# Altium schematic sheet CDU_Supply.SchDoc(CDU_Supply)
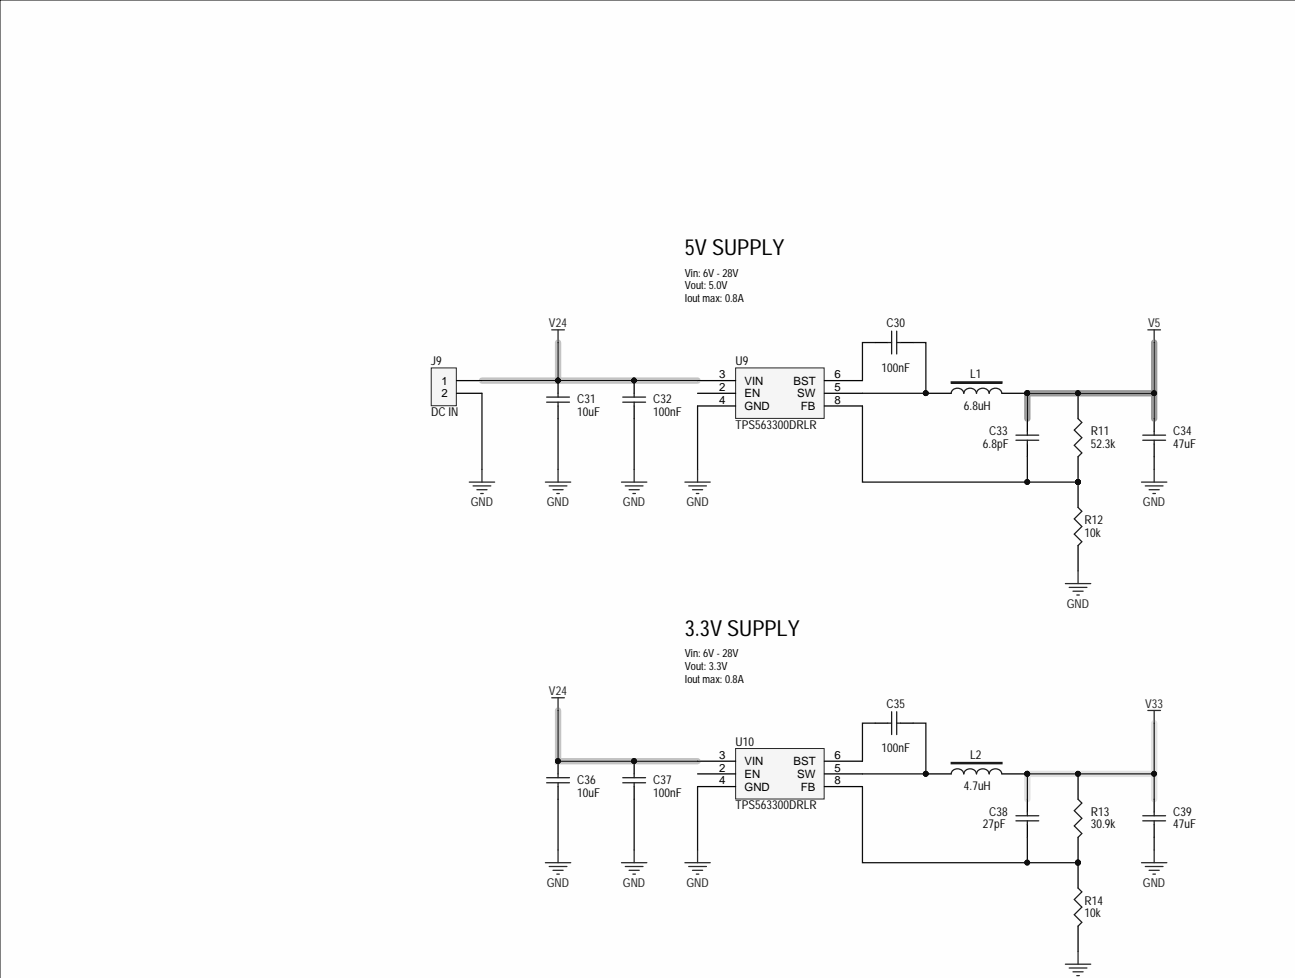
[diagram p0: CDU_Supply.SchDoc, top left]
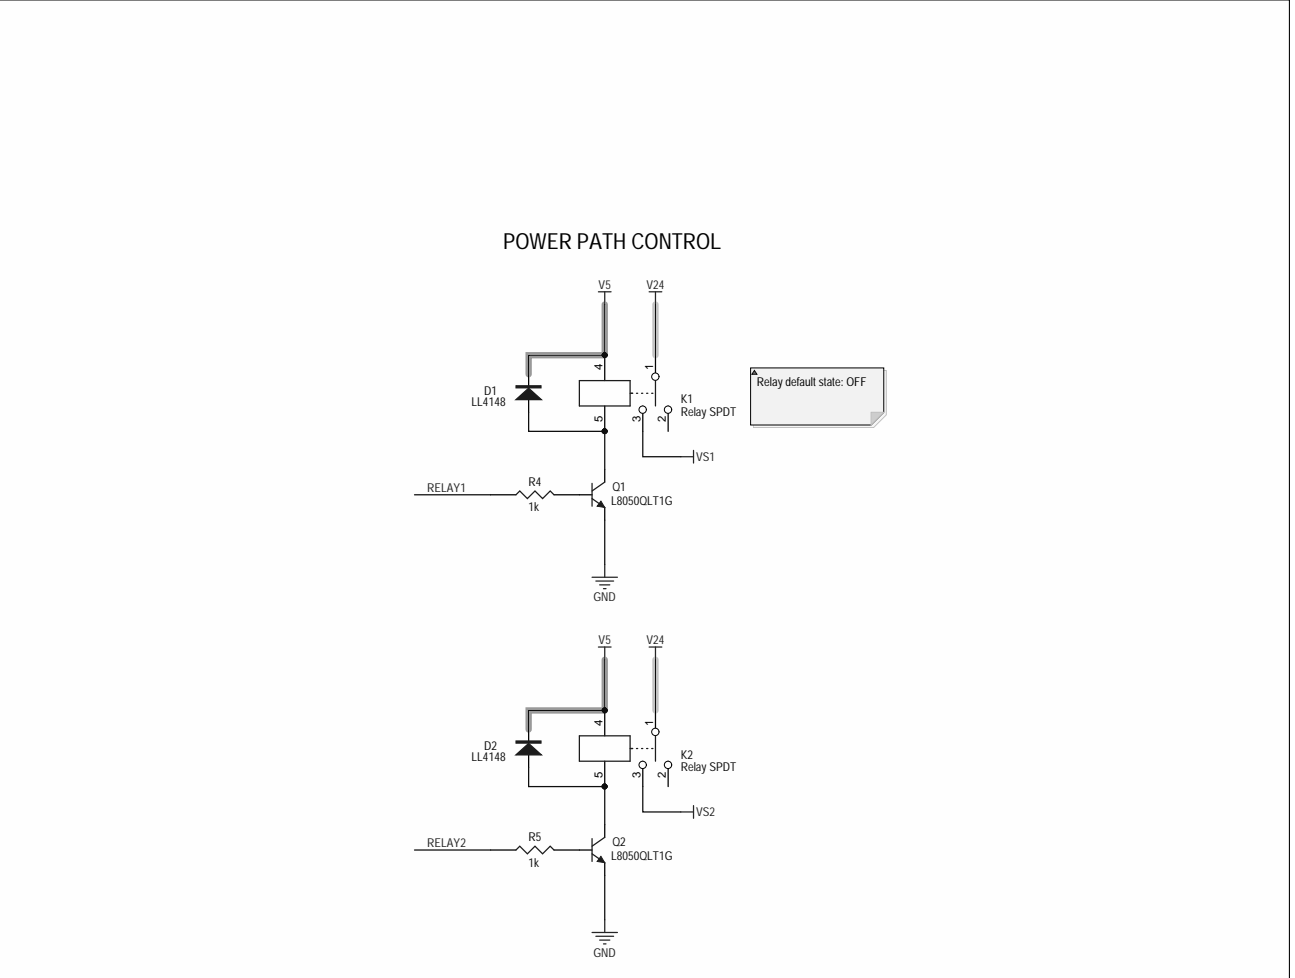
[diagram p1: CDU_Supply.SchDoc, top right]
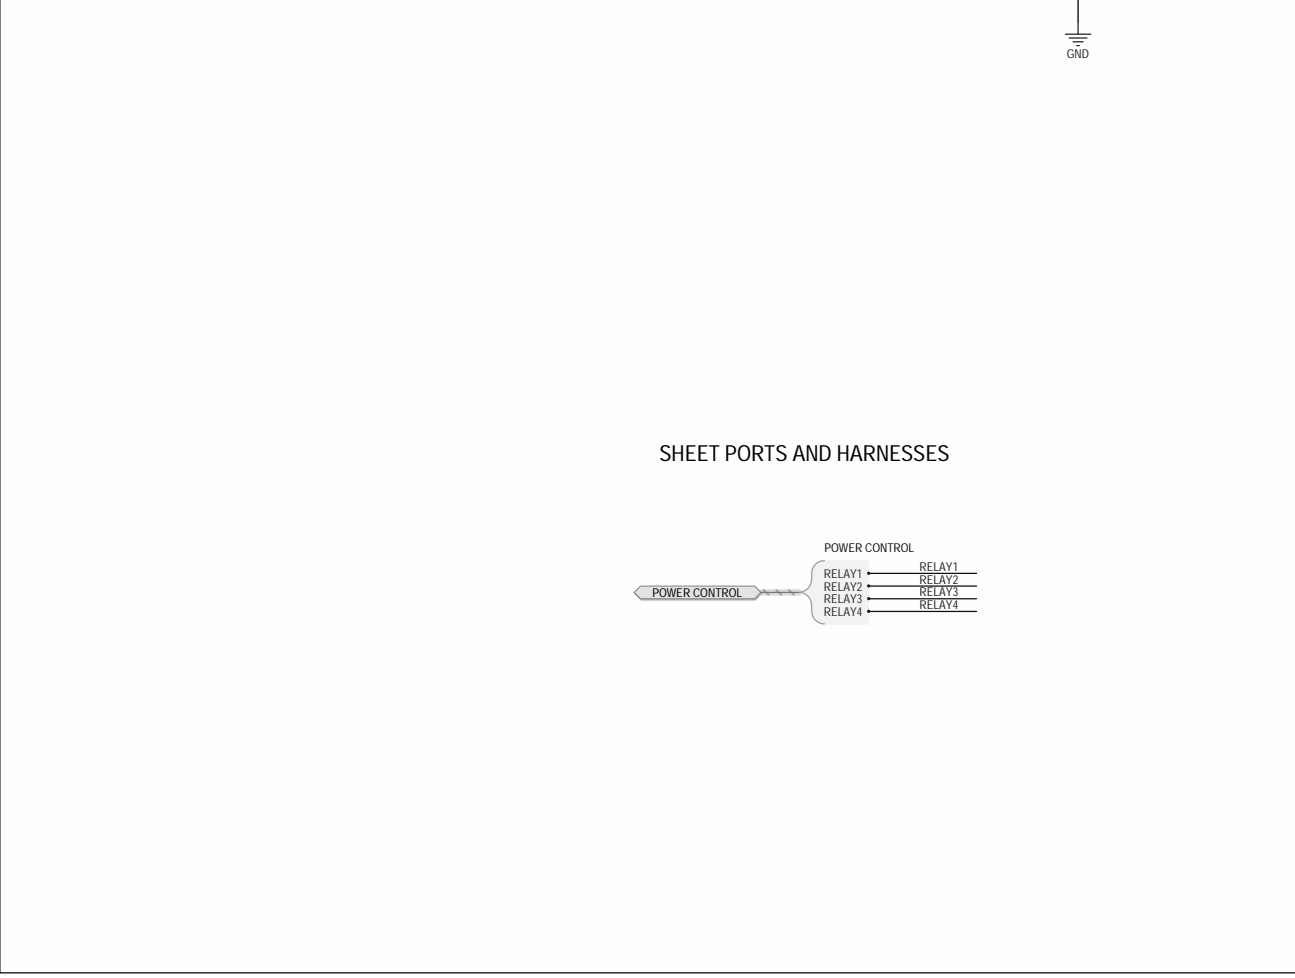
[diagram p2: CDU_Supply.SchDoc, bottom left]
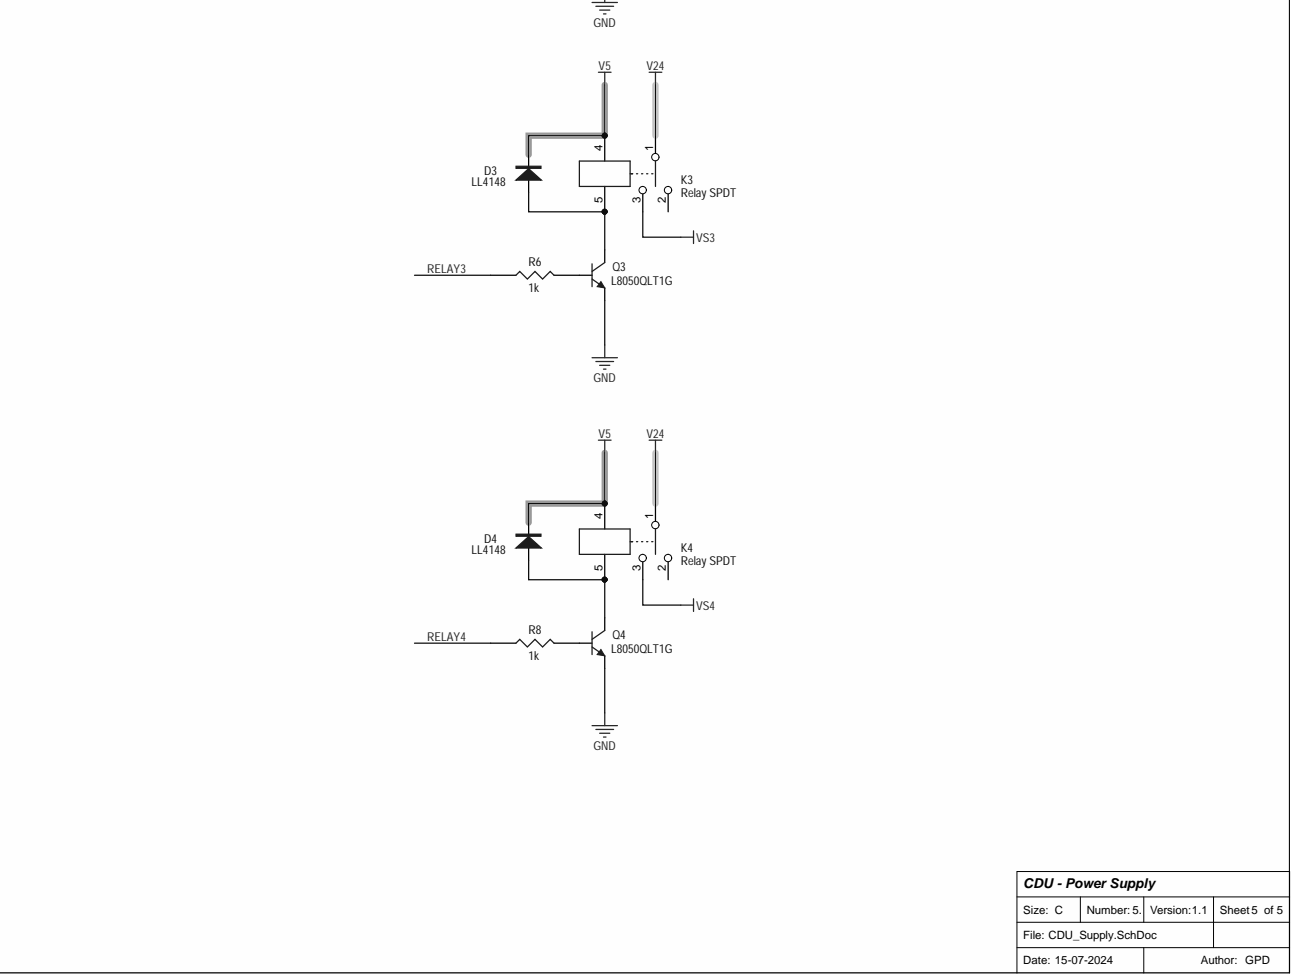
[diagram p3: CDU_Supply.SchDoc, bottom right]

## Parts
C30  100nF  [C0603]
C31  10uF  [C1206]
C32  100nF  [C0603]
C33  6.8pF  [C0402]
C34  47uF  [C1210]
C35  100nF  [C0603]
C36  10uF  [C1206]
C37  100nF  [C0603]
C38  27pF  [C0402]
C39  47uF  [C1210]
D1  LL4148  [SOD123]
D2  LL4148  [SOD123]
D3  LL4148  [SOD123]
D4  LL4148  [SOD123]
J9  DC IN  [HDR_MTA-100_2]
K1  Relay SPDT  [RELAY_AT1RC5V]
K2  Relay SPDT  [RELAY_AT1RC5V]
K3  Relay SPDT  [RELAY_AT1RC5V]
K4  Relay SPDT  [RELAY_AT1RC5V]
L1  6.8uH  [COIL9]
L2  4.7uH  [BOBINA_B82464A4]
Q1  L8050QLT1G  [NPN_SMT]
Q2  L8050QLT1G  [NPN_SMT]
Q3  L8050QLT1G  [NPN_SMT]
Q4  L8050QLT1G  [NPN_SMT]
R4  1k  [R0402]
R5  1k  [R0402]
R6  1k  [R0402]
R8  1k  [R0402]
R11  52.3k  [R0402]
R12  10k  [R0402]
R13  30.9k  [R0402]
R14  10k  [R0402]
U9  TPS563300DRLR  [SOT-5X3 - 0.6 mm]
U10  TPS563300DRLR  [SOT-5X3 - 0.6 mm]

## Ports
POWER CONTROL, POWER CONTROL.RELAY1, POWER CONTROL.RELAY2, POWER CONTROL.RELAY3, POWER CONTROL.RELAY4

## Nets
GND: C31.2 C32.2 C34.1 C36.2 C37.2 C39.1 J9.2 Q1.3 Q2.3 Q3.3 Q4.3 R12.2 R14.2 U9.4(GND) U10.4(GND)
V5: C33.1 C34.2 D1.2 D2.2 D3.2 D4.2 K1.4 K2.4 K3.4 K4.4 L1.2 R11.1
V24: C31.1 C32.1 C36.1 C37.1 J9.1 K1.1 K2.1 K3.1 K4.1 U9.3(VIN) U10.3(VIN)
V33: C38.1 C39.2 L2.2 R13.1
VS1: K1.3
VS2: K2.3
VS3: K3.3
VS4: K4.3
RELAY1: R4.1 ; port POWER CONTROL
RELAY2: R5.1 ; port POWER CONTROL
RELAY3: R6.1 ; port POWER CONTROL
RELAY4: R8.1 ; port POWER CONTROL
NetC30_1: C30.1 U9.6(BST)
NetC30_2: C30.2 L1.1 U9.5(SW)
NetC33_2: C33.2 R11.2 R12.1 U9.8(FB)
NetC35_1: C35.1 U10.6(BST)
NetC35_2: C35.2 L2.1 U10.5(SW)
NetC38_2: C38.2 R13.2 R14.1 U10.8(FB)
NetD1_1: D1.1 K1.5 Q1.1
NetD2_1: D2.1 K2.5 Q2.1
NetD3_1: D3.1 K3.5 Q3.1
NetD4_1: D4.1 K4.5 Q4.1
NetQ1_2: Q1.2 R4.2
NetQ2_2: Q2.2 R5.2
NetQ3_2: Q3.2 R6.2
NetQ4_2: Q4.2 R8.2

Not connected: K1 2; K2 2; K3 2; K4 2; U9 2(EN); U10 2(EN)

## Library data of the parts
C30 C32 C35 C37 = CAP: Multilayer Ceramic Capacitor, 0.1 uF, 50 V, ± 10%, X7R, 0603 [1608 Metric]; Manufacturer Part Number: CC0603KPX7R9BB104; Manufacturer: Yageo; Value: 100nF; Supplier Part Number 3: 603-CC603KPX7R9BB104; Supplier Part Number 2: 3764509RL; Supplier Part Number 1: 311-3567-6-ND; Supplier 3: Mouser; Supplier 2: Farnell; Supplier 1: Digikey; Library Name: Miscellaneous Devices.SchLib; Component Kind: Standard
C31 C36 = CAP: Multilayer Ceramic Capacitor, 10 uF, 50 V, ± 20%, X5R, 1206 [3216 Metric]; Manufacturer Part Number: UMK316BBJ106ML-T; Manufacturer: Taiyo Yuden; Value: 10uF; Supplier Part Number 3: C97712; Supplier Part Number 2: 963-UMK316BBJ106ML-T; Supplier Part Number 1: 587-3248-6-ND; Supplier 3: LCSC; Supplier 2: Mouser; Supplier 1: Digikey; Library Name: Miscellaneous Devices.SchLib; Component Kind: Standard
C33 = CAP: Cap Ceramic 6.8pF 25V C0G 0.25pF SMD 0402 125C Paper T/R; Manufacturer Part Number: GRM1555C1E6R8CA01D; Manufacturer: Murata; Value: 6.8pF; Supplier Part Number 3: C3877782; Supplier Part Number 2: 81-GRM1555C1E6R8CA1D; Supplier Part Number 1: 490-11371-1-ND; Supplier 3: LCSC; Supplier 2: Mouser; Supplier 1: Digikey; Library Name: Miscellaneous Devices.SchLib; Component Kind: Standard
C34 C39 = CAP: Multilayer Ceramic Capacitor, 47 uF, 16 V, ± 10%, X5R, 1210 [3225 Metric]; Manufacturer Part Number: CL32A476KOJNNNE; Manufacturer: Samsung; Value: 47uF; Supplier Part Number 3: 1276-3376-1-ND; Supplier Part Number 2: C2932477; Supplier Part Number 1: 187-CL32A476KOJNNNE; Supplier 3: Digikey; Supplier 2: LCSC; Supplier 1: Mouser; Library Name: Miscellaneous Devices.SchLib; Component Kind: Standard
C38 = CAP: Cap Ceramic 27pF 50V C0G 5% SMD 0402 150°C Punched Paper T/R; Manufacturer Part Number: CGA2B2NP01H270J050BA; Manufacturer: TDK; Value: 27pF; Supplier Part Number 3: 445-12132-1-ND; Supplier Part Number 2: 445-12132-6-ND; Supplier Part Number 1: 810-CGA2B2NP01H270JA; Supplier 3: Digikey; Supplier 2: Digikey; Supplier 1: Mouser; Library Name: Miscellaneous Devices.SchLib; Component Kind: Standard
D1-D4 = 1N4148: Rectifier Diode Small Signal Switching 100V 0.15A 4ns 2-Pin Mini-MELF T/R; Manufacturer: Diotec; Value: LL4148; Supplier Part Number 3: 637-LL4148; Supplier Part Number 2: 4878-LL4148DKR-ND; Supplier Part Number 1: 4878-LL4148CT-ND; Supplier 3: Mouser; Supplier 2: Digikey; Supplier 1: Digikey; Simulation: 1N4148; Manufacturer Part Number: LL4148; Component Kind: Standard
J9 = CON2: Header Assembly 2 Position MTA100 SPCL BEND MTA-100 Series | TE Connectivity 644874-2; Value: DC IN; Supplier Part Number 2: A114207-ND; Supplier Part Number 1: 571-644874-2; Supplier 2: Digikey; Supplier 1: Mouser; Manufacturer Part Number: 644874-2; Manufacturer: TE Connectivity; Library Name: Miscellaneous Devices.SchLib; Component Kind: Standard
K1-K4 = RELAY-SPDT: SPDT Non Latching Plugin Power Relays Coil 3VDC Contacts 10A 250VAC; Manufacturer Part Number: SRD-05VDC-SL-C; Manufacturer: Ningbo Forward Relay; Value: Relay SPDT; Supplier Part Number 3: C35449; Supplier Part Number 2: C35449; Supplier Part Number 1: C35449; Supplier 3: LCSC; Supplier 2: LCSC; Supplier 1: LCSC; Component Kind: Standard
L1 = INDUCTOR2: Power Choke Shielded Wirewound 6.8uH 20% 100KHz Ferrite 5.5A 22.2mOhm DCR T/R; Manufacturer Part Number: 7443340680; Manufacturer: Wurth Electronics; Value: 6.8uH; Supplier Part Number 3: 2211637; Supplier Part Number 2: 732-4182-6-ND; Supplier Part Number 1: 710-7443340680; Supplier 3: Farnell; Supplier 2: Digikey; Supplier 1: Mouser; Component Kind: Standard
L2 = INDUCTOR2: Fixed Ind 4.7UH 5.4A 14.5MOHM Sm; Manufacturer Part Number: CLF10040T-4R7N-H; Manufacturer: TDK; Value: 4.7uH; Supplier Part Number 3: 445-9580-1-ND; Supplier Part Number 2: 445-9580-2-ND; Supplier Part Number 1: 810-CLF10040T-4R7N-H; Supplier 3: Digikey; Supplier 2: Digikey; Supplier 1: Mouser; Component Kind: Standard
Q1-Q4 = MMBT3904: 25V 225mW 800mA NPN SOT-23 Bipolar Transistors - BJT ROHS; Manufacturer: LRC; Value: L8050QLT1G; Supplier Part Number 3: C49581; Supplier Part Number 2: C49581; Supplier Part Number 1: C49581; Supplier 3: LCSC; Supplier 2: LCSC; Supplier 1: LCSC; Simulation: MMBT3904; Manufacturer Part Number: L8050QLT1G; Component Kind: Standard
R4-R6 R8 = RES1: SMD Chip Resistor, 1 kOhm, ± 1%, 63 mW, 0402 [1005 Metric], Thick Film, General Purpose; Manufacturer Part Number: CRCW04021K00FKED; Manufacturer: Vishay Dale; Value: 1k; Supplier Part Number 3: C71623; Supplier Part Number 2: 71-CRCW0402-1.0K-E3; Supplier Part Number 1: 541-1.00KLDKR-ND; Supplier 3: LCSC; Supplier 2: Mouser; Supplier 1: Digikey; Component Kind: Standard
R11 = RES1: Res Thin Film 0402 52.3K Ohm 0.1% 0.063W(1/16W) ±25ppm/C Pad SMD T/R; Manufacturer Part Number: RT0402BRD0752K3L; Manufacturer: Yageo; Value: 52.3k; Supplier Part Number 3: YAG1450CT-ND; Supplier Part Number 2: 603-RT0402BRD0752K3L; Supplier Part Number 1: YAG1450DKR-ND; Supplier 3: Digikey; Supplier 2: Mouser; Supplier 1: Digikey; Library Name: Miscellaneous Devices.SchLib; Component Kind: Standard
R12 = RES1: Res Thin Film 0402 10K Ohm 0.1% 0.063W(1/16W) ±50ppm/C Pad SMD T/R; Manufacturer Part Number: RT0402BRE0710KL; Manufacturer: Yageo; Value: 10k; Supplier Part Number 3: YAG2305CT-ND; Supplier Part Number 2: YAG2305DKR-ND; Supplier Part Number 1: 603-RT0402BRE0710KL; Supplier 3: Digikey; Supplier 2: Digikey; Supplier 1: Mouser; Library Name: Miscellaneous Devices.SchLib; Component Kind: Standard
R13 = RES1: SMD Chip Resistor, 30.9 kOhm, ± 1%, 63 mW, 0402 [1005 Metric], Thick Film, General Purpose; Manufacturer Part Number: CRCW040230K9FKED; Manufacturer: Vishay; Value: 30.9k; Supplier Part Number 3: 2140892; Supplier Part Number 2: 541-30.9KLCT-ND; Supplier Part Number 1: 71-CRCW0402-30.9K-E3; Supplier 3: Farnell; Supplier 2: Digikey; Supplier 1: Mouser; Library Name: Miscellaneous Devices.SchLib; Component Kind: Standard
R14 = RES1: Res Thin Film 0402 10K Ohm 0.1% 0.063W(1/16W) ±50ppm/C Pad SMD T/R; Manufacturer Part Number: CRCW040210K0FKED; Manufacturer: Vishay; Value: 10k; Supplier Part Number 3: 541-10.0KLDKR-ND; Supplier Part Number 2: 71-CRCW0402-10K-E3; Supplier Part Number 1: C71617; Supplier 3: Digikey; Supplier 2: Mouser; Supplier 1: LCSC; Library Name: Miscellaneous Devices.SchLib; Component Kind: Standard
U9 U10 = TPS563300: 3.8-V to 28-V Input, 3-A synchronous buck converter in SOT-583 package 8-SOT-5X3 -40 to 150; Manufacturer: Texas Instruments; Value: TPS563300DRLR; Supplier Part Number 3: 296-TPS563300DRLRCT-ND; Supplier Part Number 2: 595-TPS563300DRLR; Supplier Part Number 1: TPS563300DRLR; Supplier 3: Digikey; Supplier 2: Mouser; Supplier 1: Texas Instruments; Manufacturer Part Number: TPS563300DRLR; Library Name: Power.SchLib; ComponentLink1URL: https://www.ti.com/lit/gpn/tps563300; ComponentLink1Description: Datasheet; Component Kind: Standard
